# Altium schematic sheet Extensibilite.SchDoc(Extensibilite)
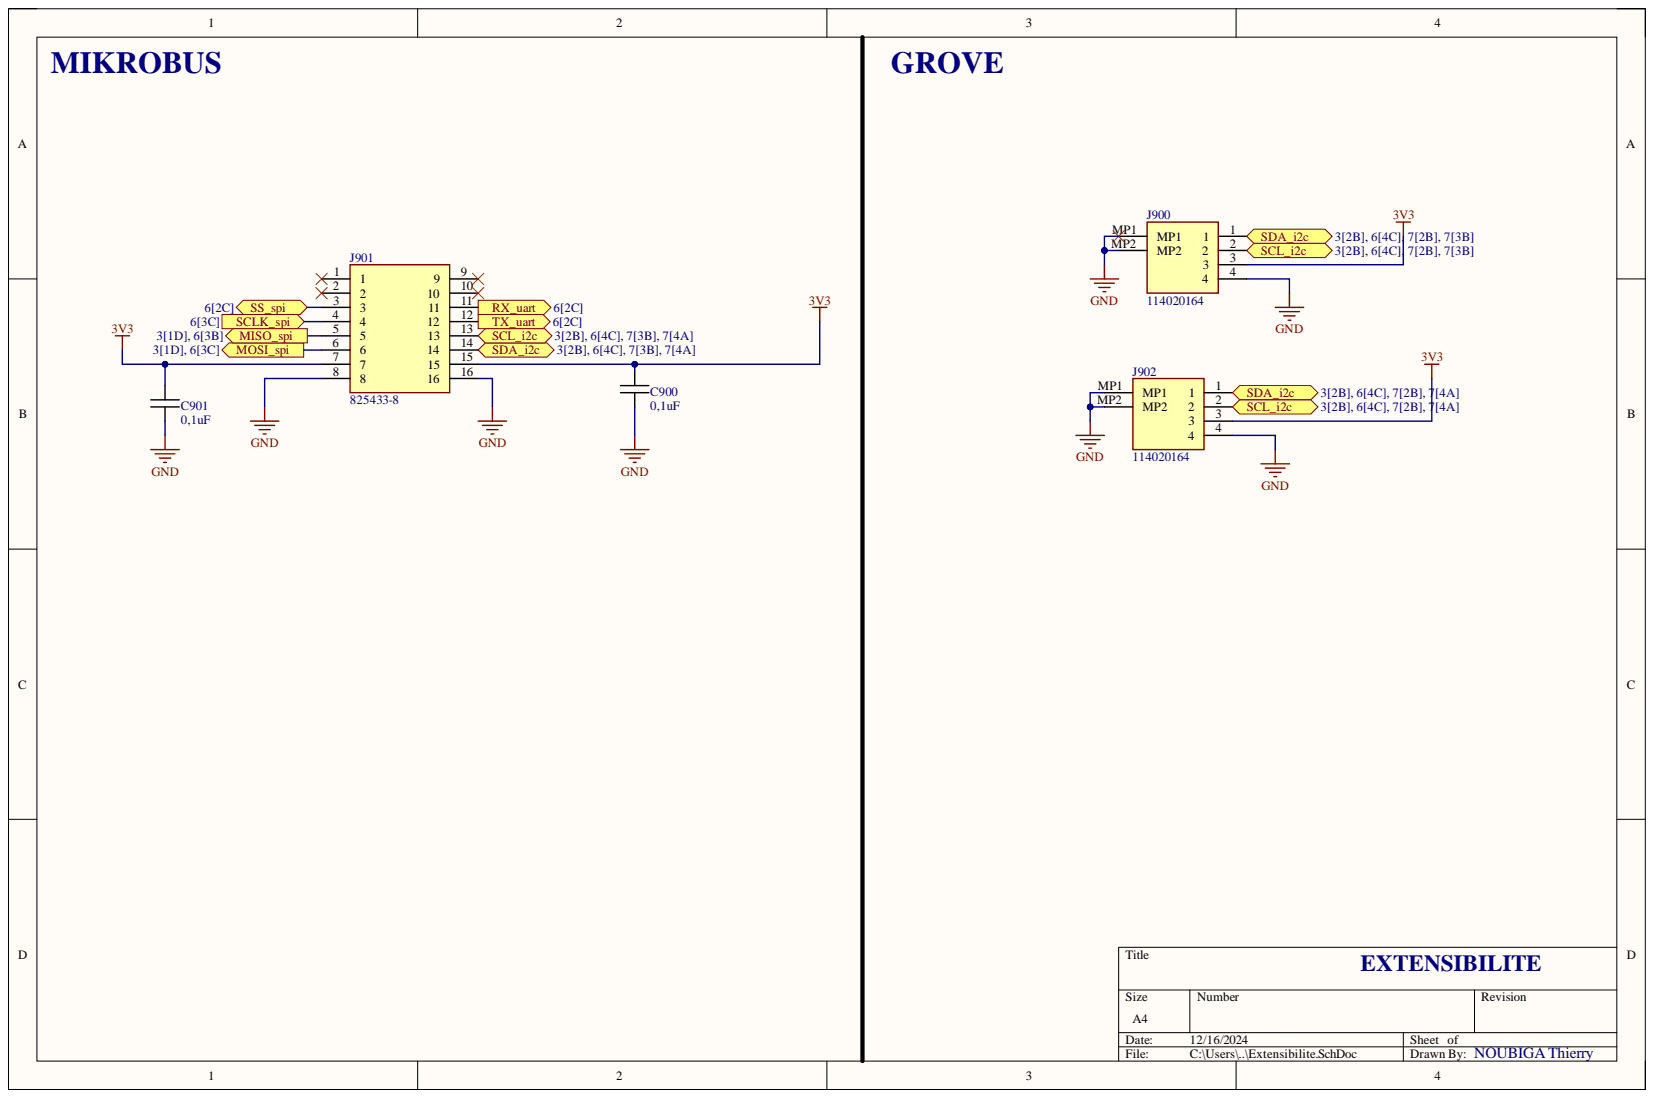
[diagram p0: Extensibilite.SchDoc]

## Parts
C900  0,1uF  [CAPC1005X06N]
C901  0,1uF  [CAPC1005X06N]
J900  114020164  [114020164]
J901  825433-8
J902  114020164  [114020164]

## Ports
MISO_spi, MOSI_spi, RX_uart, SCL_i2c, SCLK_spi, SDA_i2c, SS_spi, TX_uart

## Nets
3V3: C900.1 C901.1 J900.3 J901.7 J901.15 J902.3
GND: C900.2 C901.2 J900.4 J900.MP1 J900.MP2 J901.8 J901.16 J902.4 J902.MP1 J902.MP2
MISO_spi: J901.5 ; port MISO_spi
MOSI_spi: J901.6 ; port MOSI_spi
RX_uart: J901.11 ; port RX_uart
SCL_i2c: J900.2 J901.13 J902.2 ; port SCL_i2c
SCLK_spi: J901.4 ; port SCLK_spi
SDA_i2c: J900.1 J901.14 J902.1 ; port SDA_i2c
SS_spi: J901.3 ; port SS_spi
TX_uart: J901.12 ; port TX_uart

Not connected: J901 1 2 9 10

## Library data of the parts
C900 C901 = Capacitor: Component Kind: Standard; Library Name: SCHLibrary.SchLib
J900 J902 = 114020164: Seeed Studio Accessories Grove Female Header - SMD-4P-2.0mm-90D-20Pcs; Component Kind: Standard; Library Name: SCHLibrary.SchLib; Manufacturer_Name: Seeed Studio; Manufacturer_Part_Number: 114020164; Mouser Part Number: 713-114020164
J901 = Connect-Mikronus: Headers & Wire Housings 8P double for connection mikrobus; Manufacturer_Name: TE Connectivity; Component Kind: Standard; Library Name: SCHLibrary.SchLib; Manufacturer_Part_Number: 825433-8; Mouser Part Number: 571-825433-8
